# Altium schematic sheet clock_module.SchDoc(clock_module)
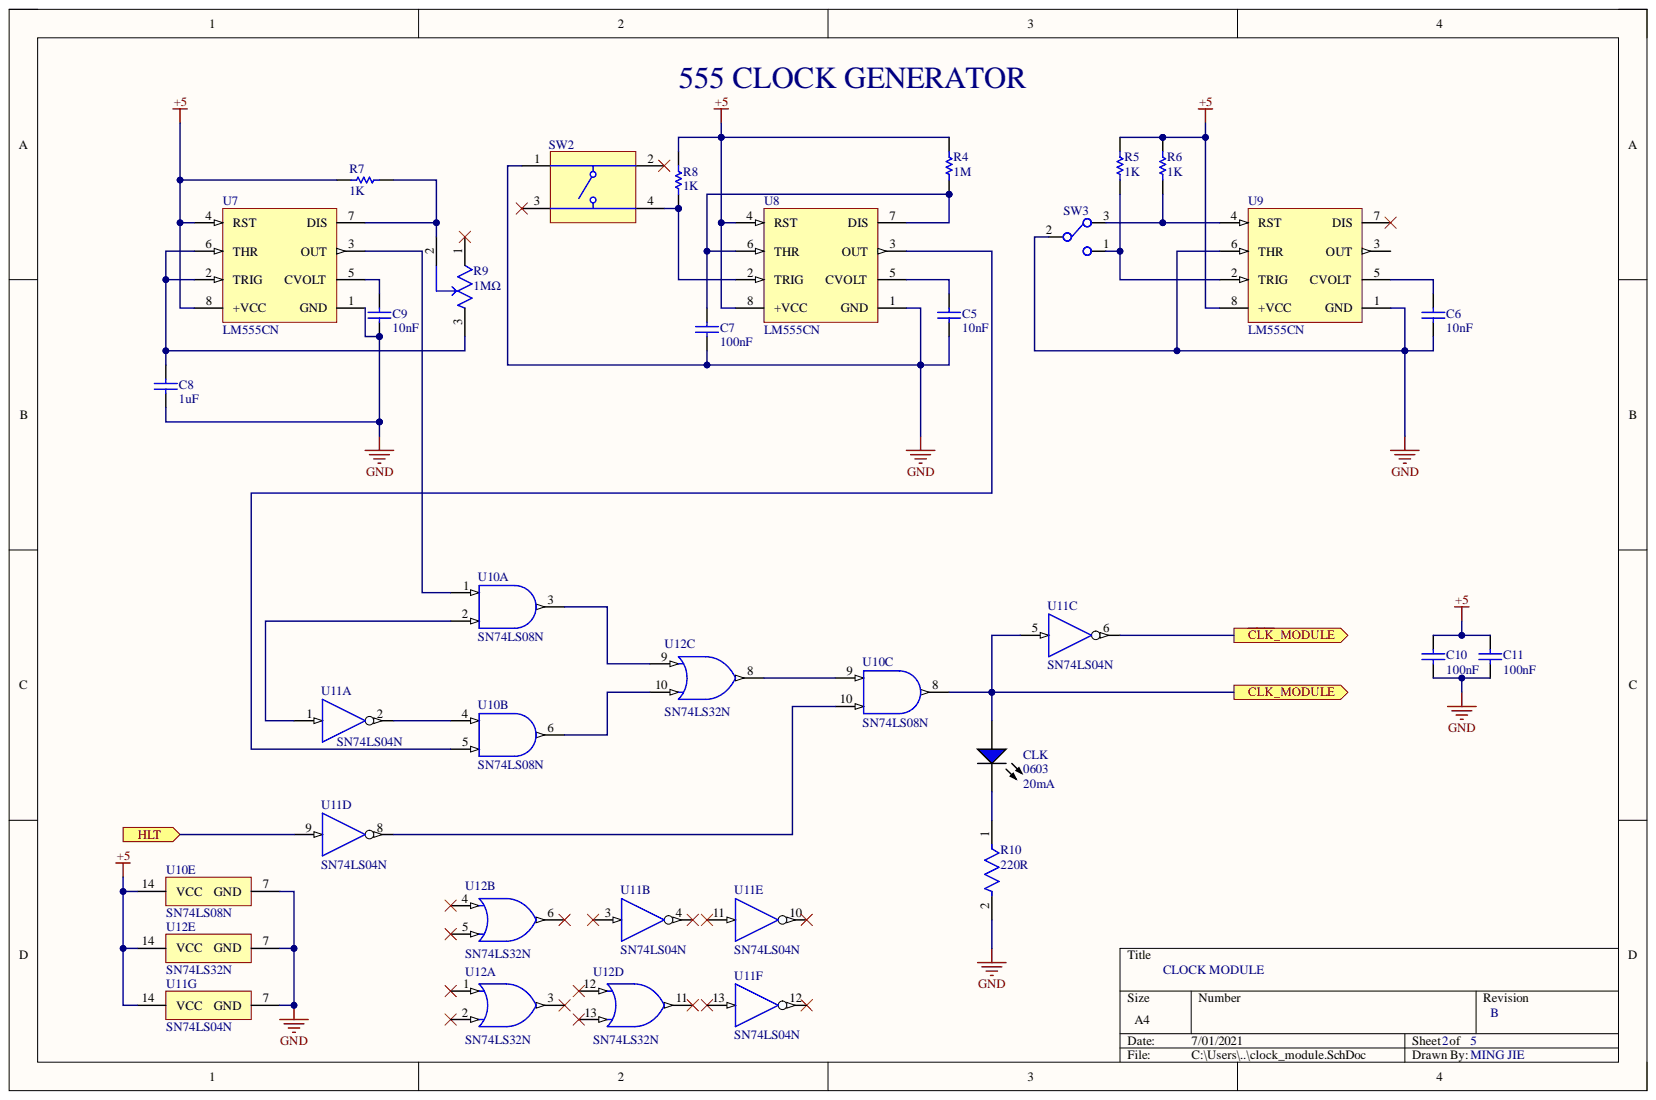
[diagram p0: clock_module.SchDoc]

## Parts
C5  Capacitance: 10nF  [CAPC2013X70X45ML10T25]  (hidden comment: GRM216R71H103KA01D)
C6  Capacitance: 10nF  [CAPC2013X70X45ML10T25]  (hidden comment: GRM216R71H103KA01D)
C7  Capacitance: 100nF  [CAPC2013X135X45ML10T25]  (hidden comment: GRM21BR71H104KA01L)
C8  Capacitance: 1uF  [CAPC2013X70X45NL10T25]  (hidden comment: GRM216R61E105KA12D)
C9  Capacitance: 10nF  [CAPC2013X70X45ML10T25]  (hidden comment: GRM216R71H103KA01D)
C10  Capacitance: 100nF  [CAPC2013X135X45ML10T25]  (hidden comment: GRM21BR71H104KA01L)
C11  Capacitance: 100nF  [CAPC2013X135X45ML10T25]  (hidden comment: GRM21BR71H104KA01L)
CLK  Package Reference: 0603  Test Current: 20mA  [EVRL-0603-2_V]  (hidden comment: 19-217/BHC-ZL1M2RY/3T)
R4  Resistance: 1M  [RESC2013X50X30NL20T20]  (hidden comment: CRCW08051M00JNEA)
R5  Resistance: 1K  [RESC2013X50X30NL20T20]  (hidden comment: CRCW08051K00FKEA)
R6  Resistance: 1K  [RESC2013X50X30NL20T20]  (hidden comment: CRCW08051K00FKEA)
R7  Resistance: 1K  [RESC2013X50X30NL20T20]  (hidden comment: CRCW08051K00FKEA)
R8  Resistance: 1K  [RESC2013X50X30NL20T20]  (hidden comment: CRCW08051K00FKEA)
R9  value 1MΩ  Resistance: 1MΩ  [FP-3296W-MFG]  (hidden comment: 3296W-1-105LF)
R10  Resistance: 220R  [FP-CRCW0805-e3-MFG]  (hidden comment: CRCW0805220RFKEA)
SW2  K2-1102SP-C4SC-04  [TECO-FSM4JSMA_V]  (value not drawn)
SW3  SS-12D02-VG4  [5_2-54mm_SPDT]  (value not drawn)
U7  LM555CN  [N08E]
U8  LM555CN  [N08E]
U9  LM555CN  [N08E]
U10  SN74LS08N  [N0014A]  (parts A,B,C,E)
U11  SN74LS04N  [N0014A]  (parts A,B,C,D,E,F,G)
U12  SN74LS32N  [N0014A]  (parts A,B,C,D,E)

## Ports
CLK_MODULE, HLT, ~{CLK}_MODULE

## Nets
+5: C10.1 C11.1 R4.1 R5.2 R6.2 R7.1 R8.2 U7.4(RST) U7.8(+VCC) U8.4(RST) U8.8(+VCC) U9.8(+VCC) U10.14(VCC) U11.14(VCC) U12.14(VCC)
GND: C5.1 C6.1 C7.2 C8.2 C9.1 C10.2 C11.2 R10.2 SW2.1 SW3.2 U7.1(GND) U8.1(GND) U9.1(GND) U9.6(THR) U10.7(GND) U11.7(GND) U12.7(GND)
~{CLK}_MODULE: U11.6 ; port ~{CLK}_MODULE
CLK_MODULE: CLK.1 U10.8 U11.5 ; port CLK_MODULE
HLT: U11.9 ; port HLT
NetC5_2: C5.2 U8.5(CVOLT)
NetC6_2: C6.2 U9.5(CVOLT)
NetC7_1: C7.1 R4.2 U8.6(THR) U8.7(DIS)
NetC8_1: C8.1 R9.3 U7.2(TRIG) U7.6(THR)
NetC9_2: C9.2 U7.5(CVOLT)
NetCLK_2: CLK.2 R10.1
NetR5_1: R5.1 SW3.1 U9.2(TRIG)
NetR6_1: R6.1 SW3.3 U9.4(RST)
NetR7_2: R7.2 R9.2 U7.7(DIS)
NetR8_1: R8.1 SW2.4 U8.2(TRIG)
NetU7_3: U7.3(OUT) U10.1
NetU8_3: U8.3(OUT) U10.5
NetU10_2: U10.2 U11.1
NetU10_3: U10.3 U12.9
NetU10_4: U10.4 U11.2
NetU10_6: U10.6 U12.10
NetU10_9: U10.9 U12.8
NetU10_10: U10.10 U11.8

Not connected: R9 1; SW2 2 3; U9 3(OUT) 7(DIS); U11 3 4 10 11 12 13; U12 1 2 3 4 5 6 11 12 13

## Library data of the parts
C5 C6 C9 = CMP-2000-05013-1: Chip Capacitor, 10 nF, +/- 10%, 50 V, -55 to 125 degC, 0805 (2012 Metric), RoHS, Tape and Reel; Manufacturer: Murata; Brand: MurataElectronics; Capacitance - pF: 10000pF; Case Height: 0.6mm; Case Length: 2mm; Case Width: 1.25mm; Dielectric: X7R; Temperature Coefficient / Code: +/-15%; Type: GeneralPurposeMLCC; Voltage Rating: 50V; Capacitance - nF: 10nF; Case Code - in: 0805; Case Code - mm: 2012; Component Kind: Standard; Datasheet URL: https://datasheet.ciiva.com/29201/452521-da-01-en-vs-kondensator-0805-29201121.pdf; Datasheet Version: Dec-10; Dimensions: 1.25mmWx2mmLx0.6mmH; Factory Pack Quantity: 4000; Library Name: Altium Content Vault; Manufacturer URL: http://www.murata.com/; Maximum Operating Temperature: +125C; Minimum Operating Temperature: -55C; Operating Temperature Range: -55Cto+125C; Package / Case: 0805(2012metric); Packaging: Reel; Product: GeneralTypeMLCCs; Product Category: MultilayerCeramicCapacitorsMLCC-SMD/SMT; RoHS: Details; Series: GRM; Termination Style: SMD/SMT; Tolerance: 10%; Voltage Rating DC: 50V
C7 C10 C11 = CMP-2100-03644-1: Chip Capacitor, 0.1 uF, +/- 10%, 50 V, -55 to 125 degC, 0805 (2012 Metric), RoHS, Tape and Reel; Manufacturer: Murata; Dielectric: X7R; Dielectric Material: Ceramic; Insulation Resistance: 10GR; Voltage: 50V; Case Code (Imperial): 0805; Case Code (Metric): 2012; Case/Package: 0805; Component Kind: Standard; Datasheet URL: http://psearch.en.murata.com/capacitor/product/GRM21BR71H104KA01%23.pdf; Datasheet Version: 42675.0; Depth: 1.25mm; Height: 1.25mm; Lead Free: LeadFree; Length: 2mm; Library Name: Altium Content Vault; Manufacturer URL: http://www.murata.com/; Material: Ceramic; Max Operating Temperature: 125degC; Min Operating Temperature: -55degC; Mount: SurfaceMount; Number of Pins: 2; Package Description: 2-Pin Surface Mount Device, Body 2 x 1.25 mm; Package Quantity: 1; Package Reference: 0805; Packaging: TapeandReel; Radiation Hardening: No; REACH SVHC: Unknown; RoHSCompliant: true; Termination: SMD/SMT; Thickness: 0.053inch; Tolerance: 10%; Voltage Rating: 50V; Voltage Rating (DC): 50V; Width: 0.049inch
C8 = CMP-2007-00957-1: Manufacturer: Murata; Component Kind: Standard; Library Name: Altium Content Vault; Part Number: GRM216R61E105KA12D
CLK = CMP-2100-03577-1: Chip LED, Blue, 5 V, 25 mA, -40 to 85 degC, 2-Pin SMD (0603), RoHS, Tape and Reel; Manufacturer: Everlight Electronics; Dominant Wavelength: 470nm; Forward Current: 25mA; Forward Voltage: 3V; Lens Style: Rectangular; Luminous Intensity: 28.5mcd; Number of Elements: 1; Power Dissipation: 110W; Viewing Angle: 120degrees; Case/Package: SMD/SMT; Colour: Blue; Component Kind: Standard; Datasheet URL: https://datasheet.ciiva.com/26901/getdatasheetpartid-420616-26901324.pdf; Datasheet Version: Rev. 1.0, 03/2003; Depth: 0.8mm; Lead Free: LeadFree; Length: 1.6mm; Library Name: Altium Content Vault; Manufacturer URL: http://www.everlight.com/; Max Operating Temperature: 85degC; Min Operating Temperature: -40degC; Mount: SurfaceMount; Number of LEDs: 1; Number of Pins: 2; Package Description: 2-Pin Surface Mount Device, Body 1.6 x 0.8 mm; Packaging: TapeandReel; Radiation Hardening: No; RoHSCompliant: true
R4 = CMP-2001-03632-1: Manufacturer: Vishay Dale; Component Kind: Standard; Library Name: Altium Content Vault; Part Number: CRCW08051M00JNEA
R5-R8 = CMP-1013-00510-2: Manufacturer: Vishay Dale; Component Kind: Standard; Library Name: Altium Content Vault; Part Number: CRCW08051K00FKEA
R9 = CMP-2000-05583-2: TRIMMER 1M OHM 0.5W PC PIN TOP; Manufacturer: Bourns; Manufacturer Part Number: 3296W-1-504LF; Standoff Height: 0.38mm; Temperature Coefficient: 100ppm/°C; Tolerance: 10%; Voltage Rating: 300V; Automotive: No; Category: Res; Component Kind: Standard; ComponentLink2Description: Datasheet; ComponentLink2URL: https://www.bourns.com/docs/Product-Datasheets/3296.pdf; Device Class L1: Passive Components; Device Class L2: Resistors; Device Class L3: Variable Resistors and Potentiometers; Digikey Description: TRIMMER 500K OHM 0.5W PC PIN TOP; Height: 12.05mm; Lead Free: Yes; Library Name: Altium Content Vault; Mouser Description: Trimmer; Cermet; Rest 500 Kilohms; PCB; 25 Turns; Pwr-Rtg 0.5W; Octopart: https://octopart.com/3296w-1-504lf-bourns-143884; Package: 3296W; Power Rating: 0.5W; RoHS: TRUE; Series: Trimpot 3296-Sealed; Temperature: -55°C to +125°C; Temperature Range High: +125°C; Temperature Range Low: -55°C
R10 = CMP-2001-03682-2: RES Thick Film, 220Ω, 1%, 0.125W, 100ppm/°C, 0805; Max Power Dissipation: 125mW; Voltage Rating: 150V; Case Code (Imperial): 0805; Case Code (Metric): 2012; Case/Package: 0805; Component Kind: Standard; Composition: Thick Film; Contact Plating: Tin; Depth: 1.25mm; Features: Automotive AEC-Q200; Height: 0.45mm; Lead Free: Lead Free; Length: 2mm; Library Name: Altium Content Vault; Max Operating Temperature: 155°C; Military Standard: Not; Min Operating Temperature: -55°C; Mount: Surface Mount; Number of Terminations: 2; Package Quantity: 10; Packaging: Tape and Reel; Power Rating: 125mW; Radiation Hardening: No; Temperature Coefficient: 100ppm/°C; Termination: SMD/SMT; Tolerance: 1%; Voltage Rating (DC): 150V; Weight: 0.000194oz; Width: 0.049inch
SW2 = CMP-2000-05602-2: Swicth, SPST, 24 V, -35 to 85 degC, 4-Pin SMD, RoHS, Tape and Reel; Manufacturer: TE Connectivity; Actuator Length: 0.055inch; Actuator Material: Polyamide; Case\/Package: SMD/SMT; Contact Current Rating: 50mA; Contact Resistance: 100mOhm; Electrical Life: 100000Cycles; Illumination Type: Illuminated; Max Voltage Rating (DC): 12V; Operating Force: 1.57N; Switch Travel: 0.25mm; Voltage Rating: 24V; Component Kind: Standard; Contact Material: CopperAlloy; Contact Plating: Silver; Current Rating: 50mA; Datasheet URL: http://www.te.com/commerce/DocumentDelivery/DDEController?Action=showdoc&DocId=Customer+Drawing%7F2-1437565-7%7FV%7Fpdf%7FEnglish%7FENG_CD_2-1437565-7_V.pdf%7F4-1437565-2; Datasheet Version: Rev. V, 02/2015; Length: 6mm; Library Name: Altium Content Vault; Manufacturer URL: http://www.te.com/; Max Operating Temperature: 85degC; Mechanical Life: 100000Cycles; Min Operating Temperature: -35degC; Mount: SurfaceMount; Mounting Technology: SurfaceMount; Orientation: Straight; Package Description: 4-Pin Surface Mount Device, Body 6 x 6 mm, Pitch 4.5 mm; Package Quantity: 750; Package Reference: FSM4JSMA; Packaging: LoosePiece; REACH SVHC: Unknown; RoHSCompliant: true; Termination: GullWing; Throw Configuration: SPST; Voltage Rating (DC): 24V; Width: 6mm
SW3 = SS-12D02-VG4: SPDT, Korean Hroparts Elec SS-12D02-VG4; Component Kind: Standard; Library Name: 6502_lib.SchLib
U7-U9 = CMP-0056-00017-2: Timer, 8-pin MDIP; Manufacturer: National Semiconductor; CompType: Analog IC; PackageTopMarking: NSUZXYTTE#*LM*555CN; PowerWise: N; Code_JEDEC: MS-001-BA; Component Kind: Standard; ComponentLink1Description: LM555 Datasheet; ComponentLink1URL: http://www.national.com/ds/LM/LM555.pdf; ComponentLink2Description: LM555 Web Page; ComponentLink2URL: http://www.national.com/pf/LM/LM555.html; ComponentLink3Description: N08E Package Page; ComponentLink3URL: http://www.national.com/packaging/folders/n08e.html; DatasheetVersion: Jul-2006; Library Name: Altium Content Vault; PackageDescription: MDIP, .365 x .250 x .130in, 8 Lead, .100in Pitch; PackageReference: N08E; PackageVersion: revG, May-2006; PinCount: 8; RevisionNote: Component URLs added; RoHS: N
U10 = CMP-0777-00259-3: Quadruple 2-Input Positive-AND Gates, N0014A, TUBE; Manufacturer: Texas Instruments; Bits(#): 4; F @ Nom Voltage(Max)(Mhz): 35; ICC @ Nom Voltage(Max)(mA): 0.021; Input Type: TTL; Output Drive (IOL/IOH)(Max)(mA): -0.8/16; Rating: Catalog; Schmitt Trigger: No; Technology Family: LS; tpd @ Nom Voltage(Max)(ns): 25; VCC(Max)(V): 5.25; VCC(Min)(V): 4.75; Voltage(Nom)(V): 5; Component Kind: Standard; DatasheetVersion: SDLS033; Generic Part Number: SN74LS08; Library Name: Altium Content Vault; Operating Temperature Range(C): 0 to 70; Output Type: TTL; PackageReference: N0014A
U11 = CMP-1615-00911-2: Hex inverters, N0014A, TUBE; Manufacturer: Texas Instruments; Bits(#): 6; F @ Nom Voltage(Max)(Mhz): 35; ICC @ Nom Voltage(Max)(mA): 0.033; Input Type: TTL; Output Drive (IOL/IOH)(Max)(mA): -0.4/8; Output Type: CMOS; Rating: Catalog; Schmitt Trigger: No; Technology Family: LS; tpd @ Nom Voltage(Max)(ns): 22; VCC(Max)(V): 5.25; VCC(Min)(V): 4.75; Voltage(Nom)(V): 5; Code_JEDEC: MS-001; Component Kind: Standard; DatasheetVersion: SDLS029C; Generic Part Number: SN74LS04; Library Name: Altium Content Vault; Operating Temperature Range(C): 0 to 70; PackageReference: N0014A
U12 = CMP-1573-00145-3: Quad 2-input positive-OR gates, N0014A, TUBE; Manufacturer: Texas Instruments; Bits(#): 4; F @ Nom Voltage(Max)(Mhz): 35; ICC @ Nom Voltage(Max)(mA): 0.038; Input Type: TTL; Output Drive (IOL/IOH)(Max)(mA): 0.8/-16; Rating: Catalog; tpd @ Nom Voltage(Max)(ns): 22; VCC(Max)(V): 5.25; VCC(Min)(V): 4.75; Voltage(Nom)(V): 5; Code_JEDEC: MS-001; Component Kind: Standard; DatasheetVersion: SDLS100; Generic Part Number: SN74LS32; Library Name: Altium Content Vault; Operating Temperature Range(C): 0 to 70; Output Type: TTL; PackageReference: N0014A; PackageVersion: http://www.ti.com/lit/ml/mpdi002c/mpdi002c.pdf
